# Altium schematic sheet OutputConnectors.SchDoc
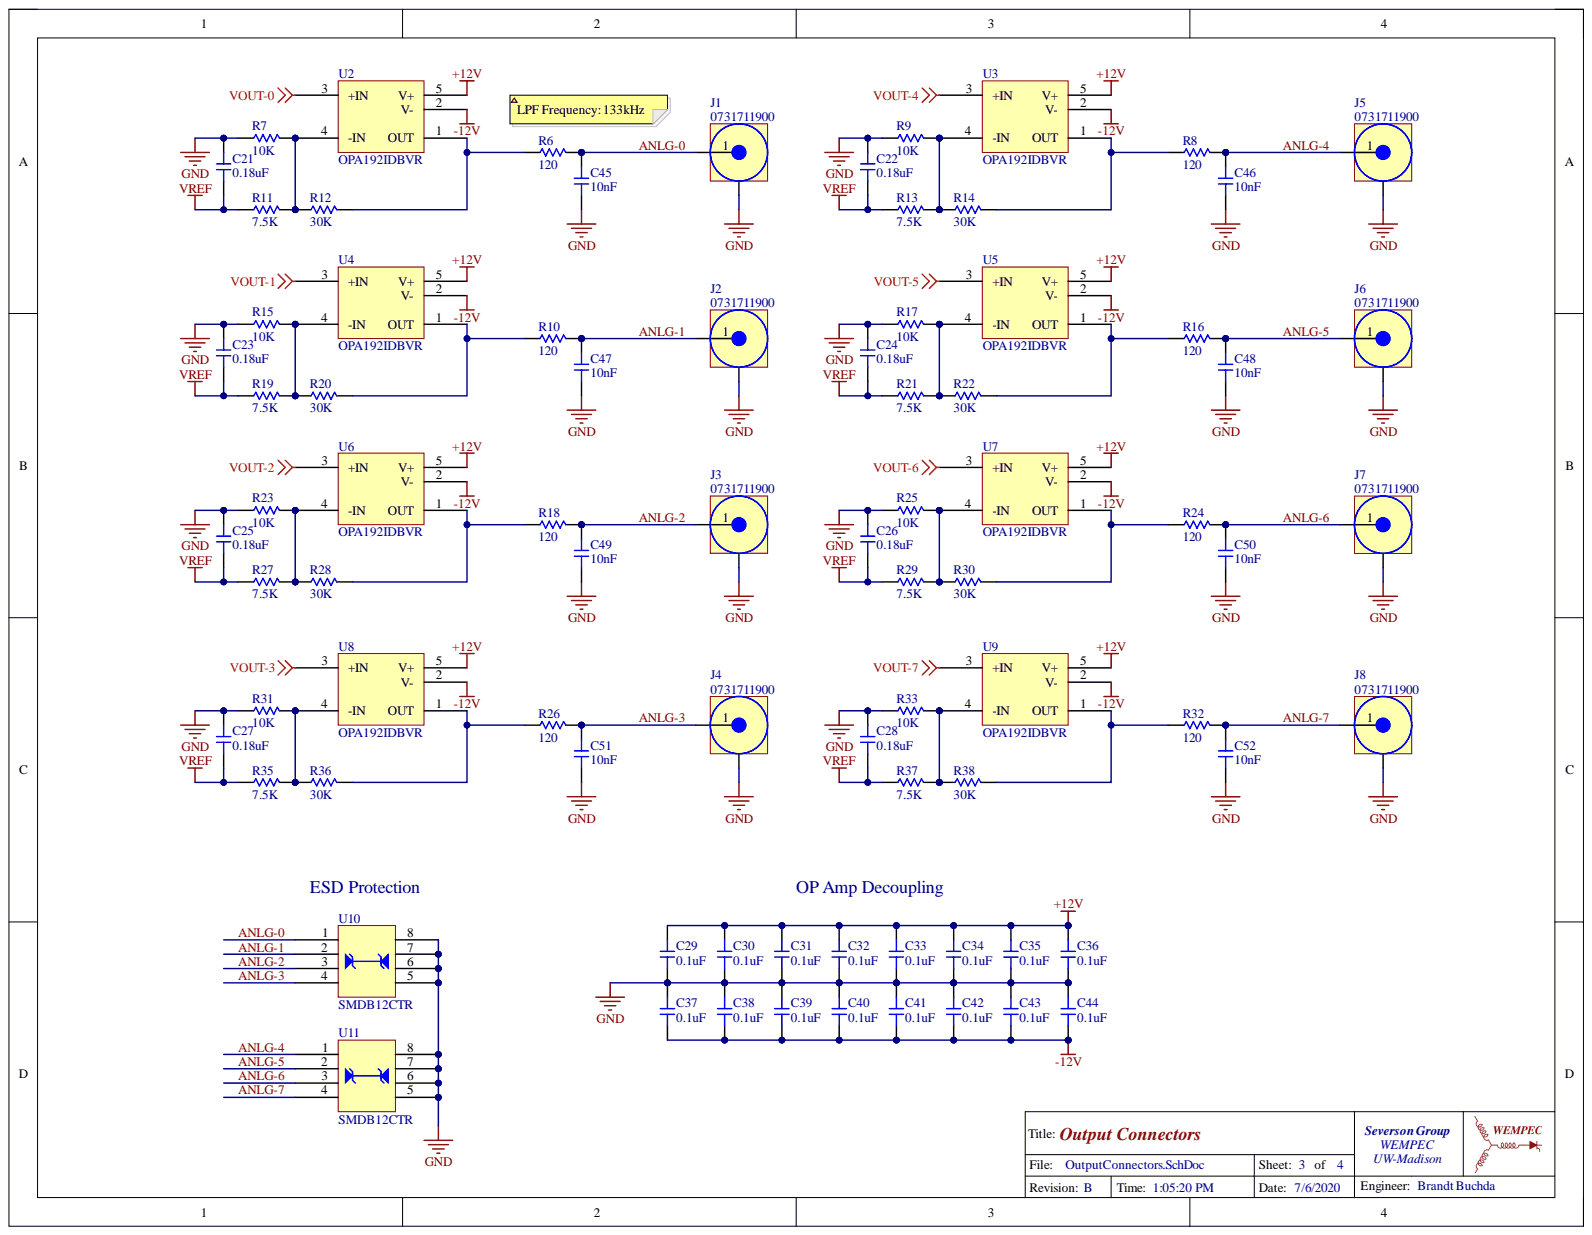
[diagram p0: OutputConnectors.SchDoc]

## Parts
C21  value 0.18uF  [0603-CAP]  (hidden comment: CC0603KRX7R7BB184)
C22  value 0.18uF  [0603-CAP]  (hidden comment: CC0603KRX7R7BB184)
C23  value 0.18uF  [0603-CAP]  (hidden comment: CC0603KRX7R7BB184)
C24  value 0.18uF  [0603-CAP]  (hidden comment: CC0603KRX7R7BB184)
C25  value 0.18uF  [0603-CAP]  (hidden comment: CC0603KRX7R7BB184)
C26  value 0.18uF  [0603-CAP]  (hidden comment: CC0603KRX7R7BB184)
C27  value 0.18uF  [0603-CAP]  (hidden comment: CC0603KRX7R7BB184)
C28  value 0.18uF  [0603-CAP]  (hidden comment: CC0603KRX7R7BB184)
C29  value 0.1uF  [0603-CAP]  (hidden comment: CC0603KRX7R8BB104)
C30  value 0.1uF  [0603-CAP]  (hidden comment: CC0603KRX7R8BB104)
C31  value 0.1uF  [0603-CAP]  (hidden comment: CC0603KRX7R8BB104)
C32  value 0.1uF  [0603-CAP]  (hidden comment: CC0603KRX7R8BB104)
C33  value 0.1uF  [0603-CAP]  (hidden comment: CC0603KRX7R8BB104)
C34  value 0.1uF  [0603-CAP]  (hidden comment: CC0603KRX7R8BB104)
C35  value 0.1uF  [0603-CAP]  (hidden comment: CC0603KRX7R8BB104)
C36  value 0.1uF  [0603-CAP]  (hidden comment: CC0603KRX7R8BB104)
C37  value 0.1uF  [0603-CAP]  (hidden comment: CC0603KRX7R8BB104)
C38  value 0.1uF  [0603-CAP]  (hidden comment: CC0603KRX7R8BB104)
C39  value 0.1uF  [0603-CAP]  (hidden comment: CC0603KRX7R8BB104)
C40  value 0.1uF  [0603-CAP]  (hidden comment: CC0603KRX7R8BB104)
C41  value 0.1uF  [0603-CAP]  (hidden comment: CC0603KRX7R8BB104)
C42  value 0.1uF  [0603-CAP]  (hidden comment: CC0603KRX7R8BB104)
C43  value 0.1uF  [0603-CAP]  (hidden comment: CC0603KRX7R8BB104)
C44  value 0.1uF  [0603-CAP]  (hidden comment: CC0603KRX7R8BB104)
C45  value 10nF  [0603-CAP]  (hidden comment: CC0603KRX7R9BB103)
C46  value 10nF  [0603-CAP]  (hidden comment: CC0603KRX7R9BB103)
C47  value 10nF  [0603-CAP]  (hidden comment: CC0603KRX7R9BB103)
C48  value 10nF  [0603-CAP]  (hidden comment: CC0603KRX7R9BB103)
C49  value 10nF  [0603-CAP]  (hidden comment: CC0603KRX7R9BB103)
C50  value 10nF  [0603-CAP]  (hidden comment: CC0603KRX7R9BB103)
C51  value 10nF  [0603-CAP]  (hidden comment: CC0603KRX7R9BB103)
C52  value 10nF  [0603-CAP]  (hidden comment: CC0603KRX7R9BB103)
J1  0731711900  [0731711900]
J2  0731711900  [0731711900]
J3  0731711900  [0731711900]
J4  0731711900  [0731711900]
J5  0731711900  [0731711900]
J6  0731711900  [0731711900]
J7  0731711900  [0731711900]
J8  0731711900  [0731711900]
R6  value 120  [0603-RES]  (hidden comment: RC0603FR-07120RL)
R7  value 10K  [0603-RES]  (hidden comment: ERJ-PB3B1002V)
R8  value 120  [0603-RES]  (hidden comment: RC0603FR-07120RL)
R9  value 10K  [0603-RES]  (hidden comment: ERJ-PB3B1002V)
R10  value 120  [0603-RES]  (hidden comment: RC0603FR-07120RL)
R11  value 7.5K  [0603-RES]  (hidden comment: ERJ-PB3B7501V)
R12  value 30K  [0603-RES]  (hidden comment: ERJ-PB3B3002V)
R13  value 7.5K  [0603-RES]  (hidden comment: ERJ-PB3B7501V)
R14  value 30K  [0603-RES]  (hidden comment: ERJ-PB3B3002V)
R15  value 10K  [0603-RES]  (hidden comment: RT0603FRE0710KL)
R16  value 120  [0603-RES]  (hidden comment: RC0603FR-07120RL)
R17  value 10K  [0603-RES]  (hidden comment: ERJ-PB3B1002V)
R18  value 120  [0603-RES]  (hidden comment: RC0603FR-07120RL)
R19  value 7.5K  [0603-RES]  (hidden comment: ERJ-PB3B7501V)
R20  value 30K  [0603-RES]  (hidden comment: ERJ-PB3B3002V)
R21  value 7.5K  [0603-RES]  (hidden comment: ERJ-PB3B7501V)
R22  value 30K  [0603-RES]  (hidden comment: ERJ-PB3B3002V)
R23  value 10K  [0603-RES]  (hidden comment: ERJ-PB3B1002V)
R24  value 120  [0603-RES]  (hidden comment: RC0603FR-07120RL)
R25  value 10K  [0603-RES]  (hidden comment: ERJ-PB3B1002V)
R26  value 120  [0603-RES]  (hidden comment: RC0603FR-07120RL)
R27  value 7.5K  [0603-RES]  (hidden comment: ERJ-PB3B7501V)
R28  value 30K  [0603-RES]  (hidden comment: ERJ-PB3B3002V)
R29  value 7.5K  [0603-RES]  (hidden comment: ERJ-PB3B7501V)
R30  value 30K  [0603-RES]  (hidden comment: ERJ-PB3B3002V)
R31  value 10K  [0603-RES]  (hidden comment: ERJ-PB3B1002V)
R32  value 120  [0603-RES]  (hidden comment: RC0603FR-07120RL)
R33  value 10K  [0603-RES]  (hidden comment: ERJ-PB3B1002V)
R35  value 7.5K  [0603-RES]  (hidden comment: ERJ-PB3B7501V)
R36  value 30K  [0603-RES]  (hidden comment: ERJ-PB3B3002V)
R37  value 7.5K  [0603-RES]  (hidden comment: ERJ-PB3B7501V)
R38  value 30K  [0603-RES]  (hidden comment: ERJ-PB3B3002V)
U2  OPA192IDBVR  [SOT95P280X145-5N]
U3  OPA192IDBVR  [SOT95P280X145-5N]
U4  OPA192IDBVR  [SOT95P280X145-5N]
U5  OPA192IDBVR  [SOT95P280X145-5N]
U6  OPA192IDBVR  [SOT95P280X145-5N]
U7  OPA192IDBVR  [SOT95P280X145-5N]
U8  OPA192IDBVR  [SOT95P280X145-5N]
U9  OPA192IDBVR  [SOT95P280X145-5N]
U10  SMDB12CTR  [SOIC127P600X180-8N]
U11  SMDB12CTR  [SOIC127P600X180-8N]

## Nets
+12V: C29.2 C30.2 C31.2 C32.2 C33.2 C34.2 C35.2 C36.2 U2.5(V+) U3.5(V+) U4.5(V+) U5.5(V+) U6.5(V+) U7.5(V+) U8.5(V+) U9.5(V+)
-12V: C37.1 C38.1 C39.1 C40.1 C41.1 C42.1 C43.1 C44.1 U2.2(V-) U3.2(V-) U4.2(V-) U5.2(V-) U6.2(V-) U7.2(V-) U8.2(V-) U9.2(V-)
GND: C21.2 C22.2 C23.2 C24.2 C25.2 C26.2 C27.2 C28.2 C29.1 C30.1 C31.1 C32.1 C33.1 C34.1 C35.1 C36.1 C37.2 C38.2 C39.2 C40.2 C41.2 C42.2 C43.2 C44.2 C45.1 C46.1 C47.1 C48.1 C49.1 C50.1 C51.1 C52.1 J1.2 J2.2 J3.2 J4.2 J5.2 J6.2 J7.2 J8.2 R7.1 R9.1 R15.1 R17.1 R23.1 R25.1 R31.1 R33.1 U10.5 U10.6 U10.7 U10.8 U11.5 U11.6 U11.7 U11.8
VOUT-0: U2.3(+IN)
VOUT-1: U4.3(+IN)
VOUT-2: U6.3(+IN)
VOUT-3: U8.3(+IN)
VOUT-4: U3.3(+IN)
VOUT-5: U5.3(+IN)
VOUT-6: U7.3(+IN)
VOUT-7: U9.3(+IN)
VREF: C21.1 C22.1 C23.1 C24.1 C25.1 C26.1 C27.1 C28.1 R11.1 R13.1 R19.1 R21.1 R27.1 R29.1 R35.1 R37.1
ANLG-0: C45.2 J1.1 R6.2 U10.1
ANLG-1: C47.2 J2.1 R10.2 U10.2
ANLG-2: C49.2 J3.1 R18.2 U10.3
ANLG-3: C51.2 J4.1 R26.2 U10.4
ANLG-4: C46.2 J5.1 R8.2 U11.1
ANLG-5: C48.2 J6.1 R16.2 U11.2
ANLG-6: C50.2 J7.1 R24.2 U11.3
ANLG-7: C52.2 J8.1 R32.2 U11.4
NetR6_1: R6.1 R12.2 U2.1(OUT)
NetR7_2: R7.2 R11.2 R12.1 U2.4(-IN)
NetR8_1: R8.1 R14.2 U3.1(OUT)
NetR9_2: R9.2 R13.2 R14.1 U3.4(-IN)
NetR10_1: R10.1 R20.2 U4.1(OUT)
NetR15_2: R15.2 R19.2 R20.1 U4.4(-IN)
NetR16_1: R16.1 R22.2 U5.1(OUT)
NetR17_2: R17.2 R21.2 R22.1 U5.4(-IN)
NetR18_1: R18.1 R28.2 U6.1(OUT)
NetR23_2: R23.2 R27.2 R28.1 U6.4(-IN)
NetR24_1: R24.1 R30.2 U7.1(OUT)
NetR25_2: R25.2 R29.2 R30.1 U7.4(-IN)
NetR26_1: R26.1 R36.2 U8.1(OUT)
NetR31_2: R31.2 R35.2 R36.1 U8.4(-IN)
NetR32_1: R32.1 R38.2 U9.1(OUT)
NetR33_2: R33.2 R37.2 R38.1 U9.4(-IN)

## Library data of the parts
C21-C28 = Capacitor 0.18uF: 0.18µF ±10% 16V Ceramic Capacitor X7R 0603 (1608 Metric); Component Kind: Standard; Simulation: CAP; Supplier 1: Digi-Key; Supplier Part Number 1: 311-4066-1-ND; Library Name: DAC.SchLib
C29-C44 = Capacitor 0.1uF: 0.1µF ±10% 25V Ceramic Capacitor X7R 0603 (1608 Metric); Component Kind: Standard; Simulation: CAP; Supplier 1: Digi-Key; Supplier Part Number 1: 311-1341-1-ND; Library Name: DAC.SchLib
C45-C52 = Capacitor 10nF: 10000pF ±10% 50V Ceramic Capacitor X7R 0603 (1608 Metric); Component Kind: Standard; Simulation: CAP; Supplier 1: Digi-Key; Supplier Part Number 1: 311-1085-1-ND; Library Name: DAC.SchLib
J1-J8 = 0731711900: CONN BNC JACK STR 75 OHM PCB; Component Kind: Standard; Supplier 1: Digi-Key; Supplier Part Number 1: WM5517-ND; Library Name: DAC.SchLib
R6 R8 R10 R16 R18 R24 R26 R32 = Resistor 120: 120 Ohms ±1% 0.1W, 1/10W Chip Resistor 0603 (1608 Metric) Moisture Resistant Thick Film; Component Kind: Standard; Simulation: RES; Supplier 1: Digi-Key; Supplier Part Number 1: 311-120HRCT-ND; Library Name: DAC.SchLib
R7 R9 R17 R23 R25 R31 R33 = Resistor 10K: 10 kOhms ±0.1% 0.2W, 1/5W Chip Resistor 0603 (1608 Metric) Automotive AEC-Q200, Pulse Withstanding Thick Film; Component Kind: Standard; Simulation: RES; Supplier 1: Digi-Key; Supplier Part Number 1: P20086CT-ND; Library Name: DAC.SchLib
R11 R13 R19 R21 R27 R29 R35 R37 = Resistor 7.5K: 7.5 kOhms ±0.1% 0.2W, 1/5W Chip Resistor 0603 (1608 Metric) Automotive AEC-Q200, Pulse Withstanding Thick Film; Component Kind: Standard; Simulation: RES; Supplier 1: Digi-Key; Supplier Part Number 1: P20072CT-ND; Library Name: DAC.SchLib
R12 R14 R20 R22 R28 R30 R36 R38 = Resistor 30K: 30 kOhms ±0.1% 0.2W, 1/5W Chip Resistor 0603 (1608 Metric) Automotive AEC-Q200, Pulse Withstanding Thick Film; Component Kind: Standard; Simulation: RES; Supplier 1: Digi-Key; Supplier Part Number 1: P20138CT-ND; Library Name: DAC.SchLib
R15 = Resistor 10K: 10 kOhms ±1% 0.1W, 1/10W Chip Resistor 0603 (1608 Metric)  Thin Film; Component Kind: Standard; Simulation: RES; Supplier 1: Digi-Key; Supplier Part Number 1: YAG2321CT-ND; Library Name: DAC.SchLib
U2-U9 = OPA192IDBVR: General Purpose Amplifier 1 Circuit Rail-to-Rail SOT-23-5T-23-5; Component Kind: Standard; Supplier 1: Digi-Key; Supplier Part Number 1: 296-42105-1-ND; Library Name: DAC.SchLib
U10 U11 = SMDB12CTR: 19V Clamp 1A Ipp Tvs Diode Surface Mount 8-SO; Component Kind: Standard; Supplier 1: Digi-Key; Supplier Part Number 1: 1655-1983-1-ND; Library Name: DAC.SchLib
